# Altium schematic sheet Sonar.SchDoc
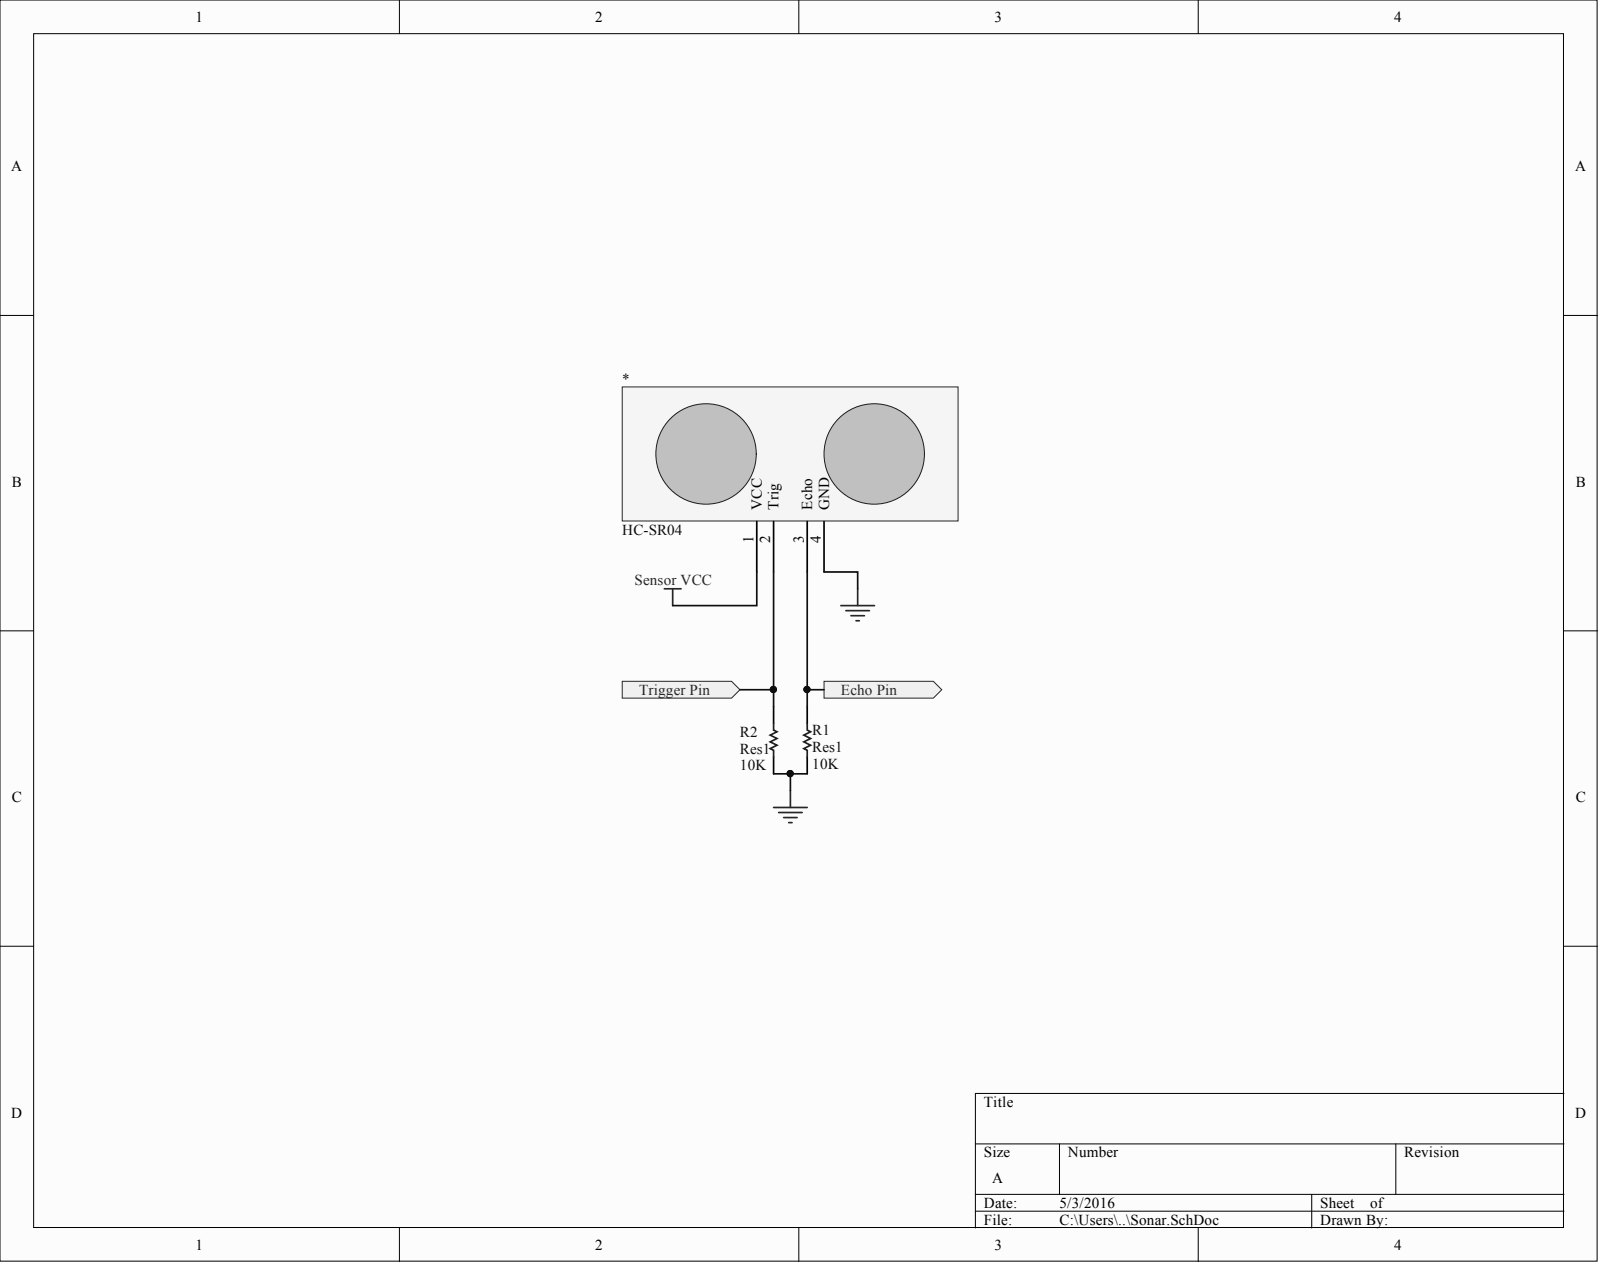
[diagram p0: Sonar.SchDoc]

## Parts
*  HC-SR04
R1  Res1  value 10K  [AXIAL-0.3]
R2  Res1  value 10K  [AXIAL-0.3]

## Ports
ECHO PIN, TRIGGER PIN

## Nets
GND: *.4(GND) R1.1 R2.1
SENSOR VCC: *.1(VCC)
Net*_2: *.2(Trig) R2.2 ; port TRIGGER PIN
Net*_3: *.3(Echo) R1.2 ; port ECHO PIN

## Library data of the parts
R1 R2 = Res1: Resistor; Component Kind: Standard; LatestRevisionDate: 17-Jul-2002; LatestRevisionNote: Re-released for DXP Platform.; Library Name: Miscellaneous Devices.IntLib; PackageDescription: Axial Device, Thru-Hole; 2 Leads; 0.3 in Pin Spacing; PackageReference: AXIAL-0.3; Published: 8-Jun-2000; Signal Integrity: Res; Simulation: RESISTOR; Publisher: Altium Limited
* = HC-SR04: Component Kind: Standard; Library Name: POC_Marvin.SchLib
